# Altium schematic sheet Amp_op2.SchDoc(Amp_op2)
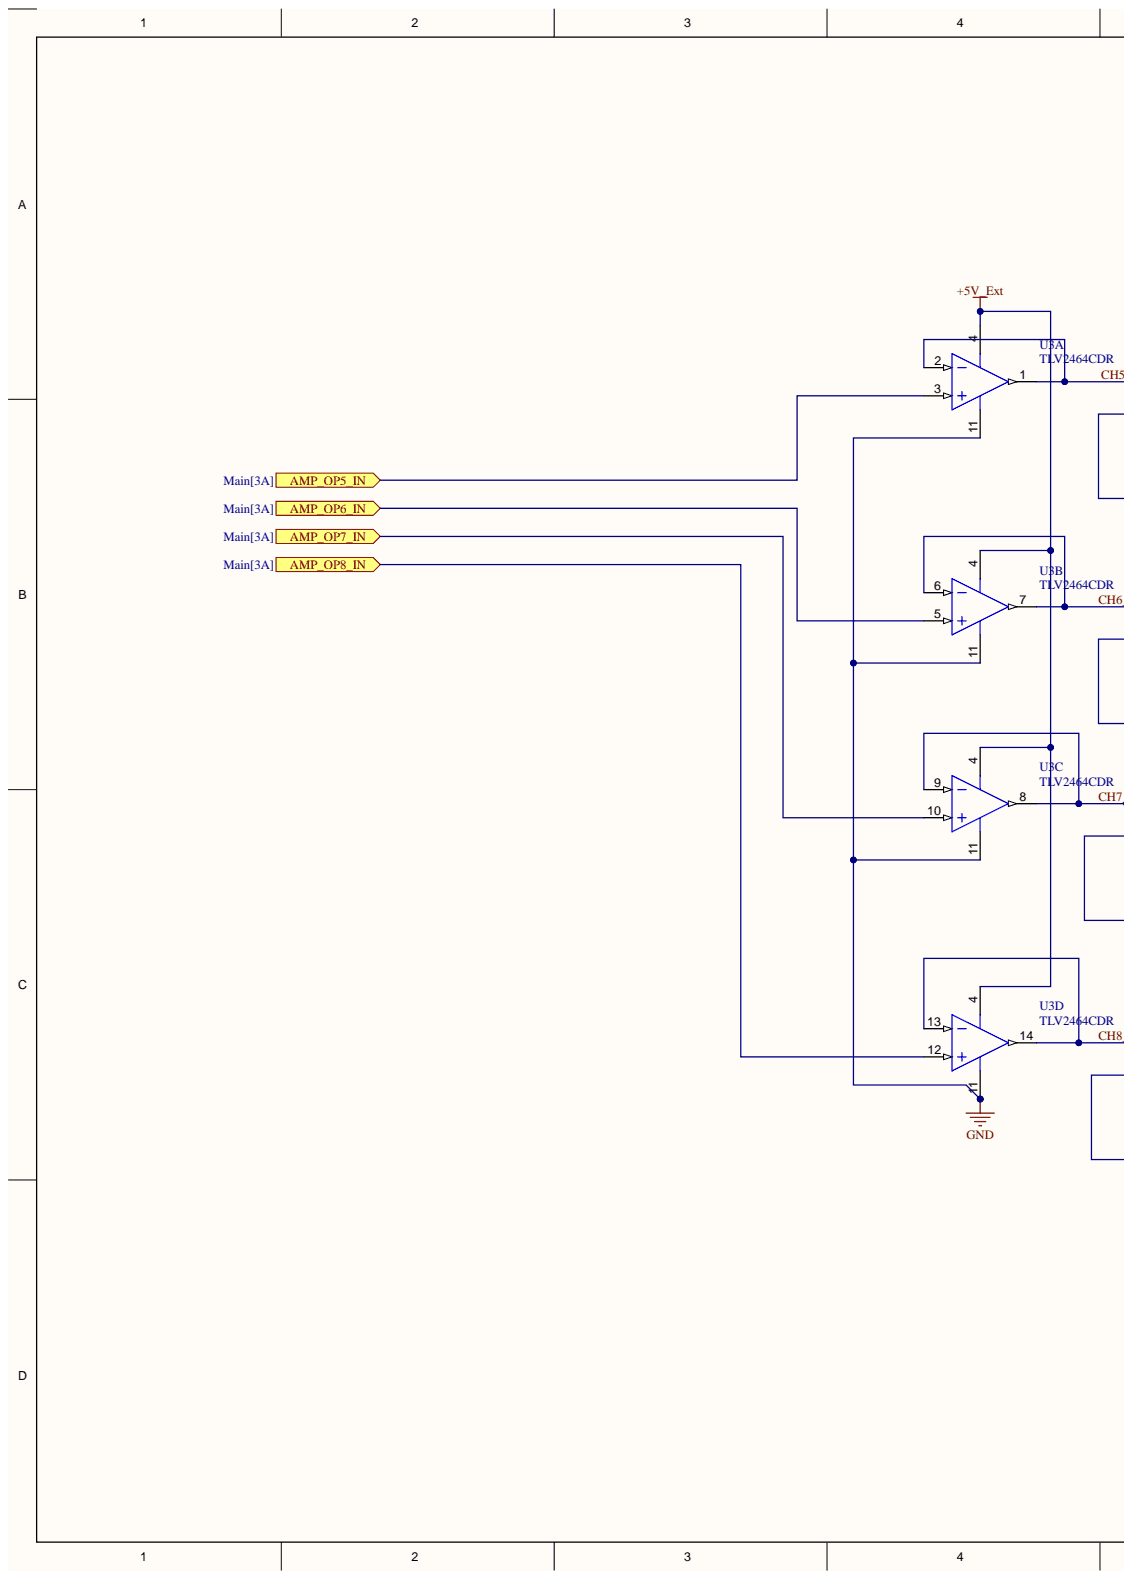
[diagram p0: Amp_op2.SchDoc, left]
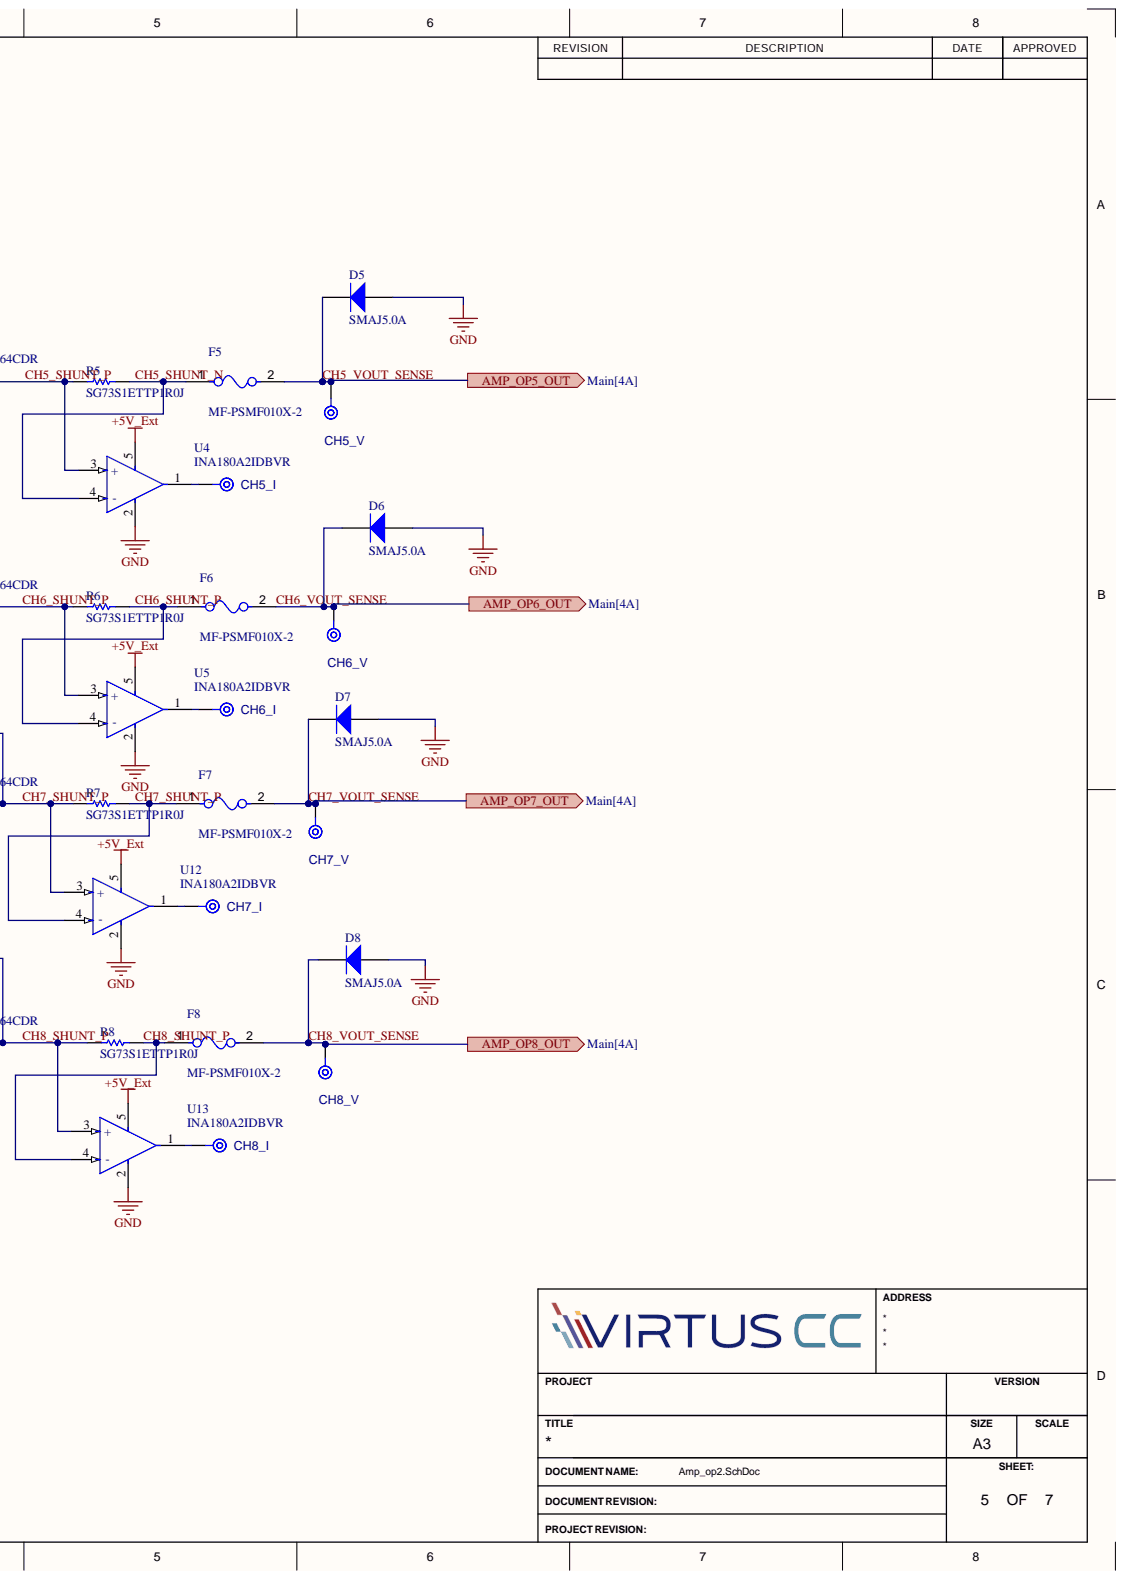
[diagram p1: Amp_op2.SchDoc, right]

## Parts
CH5_I  TPAD6R  [TP06R]  (value not drawn)  (no BOM)
CH5_V  TPAD6R  [TP06R]  (value not drawn)  (no BOM)
CH6_I  TPAD6R  [TP06R]  (value not drawn)  (no BOM)
CH6_V  TPAD6R  [TP06R]  (value not drawn)  (no BOM)
CH7_I  TPAD6R  [TP06R]  (value not drawn)  (no BOM)
CH7_V  TPAD6R  [TP06R]  (value not drawn)  (no BOM)
CH8_I  TPAD6R  [TP06R]  (value not drawn)  (no BOM)
CH8_V  TPAD6R  [TP06R]  (value not drawn)  (no BOM)
D5  SMAJ5.0A  [BOUR-SMA-2_V]
D6  SMAJ5.0A  [BOUR-SMA-2_V]
D7  SMAJ5.0A  [BOUR-SMA-2_V]
D8  SMAJ5.0A  [BOUR-SMA-2_V]
F5  MF-PSMF010X-2  [FP-MF-PSMF-MFG]
F6  MF-PSMF010X-2  [FP-MF-PSMF-MFG]
F7  MF-PSMF010X-2  [FP-MF-PSMF-MFG]
F8  MF-PSMF010X-2  [FP-MF-PSMF-MFG]
R5  SG73S1ETTP1R0J  [RESC1005X40X25LL10T10]
R6  SG73S1ETTP1R0J  [RESC1005X40X25LL10T10]
R7  SG73S1ETTP1R0J  [RESC1005X40X25LL10T10]
R8  SG73S1ETTP1R0J  [RESC1005X40X25LL10T10]
U3  TLV2464CDR  [D0014A_N]  (parts A,B,C,D)
U4  INA180A2IDBVR  [FP-DBV0005A-MFG]
U5  INA180A2IDBVR  [FP-DBV0005A-MFG]
U12  INA180A2IDBVR  [FP-DBV0005A-MFG]
U13  INA180A2IDBVR  [FP-DBV0005A-MFG]

## Ports
AMP_OP5_IN, AMP_OP5_OUT, AMP_OP6_IN, AMP_OP6_OUT, AMP_OP7_IN, AMP_OP7_OUT, AMP_OP8_IN, AMP_OP8_OUT

## Nets
+5V_Ext: U3.4 U4.5 U5.5 U12.5 U13.5
GND: D5.1 D6.1 D7.1 D8.1 U3.11 U4.2 U5.2 U12.2 U13.2
AMP_OP5_IN: U3.3 ; port AMP_OP5_IN
AMP_OP6_IN: U3.5 ; port AMP_OP6_IN
AMP_OP7_IN: U3.10 ; port AMP_OP7_IN
AMP_OP8_IN: U3.12 ; port AMP_OP8_IN
CH5_SHUNT_N: F5.1 R5.2 U4.4(-)
CH5_SHUNT_P: R5.1 U3.1 U3.2 U4.3(+)
CH5_VOUT_SENSE: CH5_V.1 D5.2 F5.2 ; port AMP_OP5_OUT
CH6_SHUNT_P: F6.1 R6.1 R6.2 U3.6 U3.7 U5.3(+) U5.4(-)
CH6_VOUT_SENSE: CH6_V.1 D6.2 F6.2 ; port AMP_OP6_OUT
CH7_SHUNT_P: F7.1 R7.1 R7.2 U3.8 U3.9 U12.3(+) U12.4(-)
CH7_VOUT_SENSE: CH7_V.1 D7.2 F7.2 ; port AMP_OP7_OUT
CH8_SHUNT_P: F8.1 R8.1 R8.2 U3.13 U3.14 U13.3(+) U13.4(-)
CH8_VOUT_SENSE: CH8_V.1 D8.2 F8.2 ; port AMP_OP8_OUT
NetCH5_I_1: CH5_I.1 U4.1
NetCH6_I_1: CH6_I.1 U5.1
NetCH7_I_1: CH7_I.1 U12.1
NetCH8_I_1: CH8_I.1 U13.1

## Library data of the parts
CH5_I CH5_V CH6_I CH6_V CH7_I CH7_V CH8_I CH8_V = CMP-00028-00002-2: 0.6mm round; Pins: 1; Library Name: UFCG - Universidade Federal de Campina Grande
D5-D8 = CMP-2000-07090-1: SMAJ Transient Voltage Suppressor Diode Series, 3.5 V, -55 to 150 degC, 2-Pin SMA, RoHS, Tape and Reel; Manufacturer: Bourns; Catalog Drawings: SMAJSeriesFootprintSMAJ,SMBJSeries; Current - Peak Pulse (10/1000µs): 43.5A; EDA / CAD Models: DownloadfromAcceleratedDesigns; Featured Product: SMAJ/SMBJ/SMCJ/SMLJDiscreteTVSDiodes; Online Catalog: SMAJSeries; Other Names: SMAJ5.0ABDKR; Power - Peak Pulse: 400W; Power Line Protection: No; Type: Zener; Unidirectional Channels: 1; Voltage - Breakdown (Min): 6.4V; Voltage - Clamping (Max) @ Ipp: 9.2V; Voltage - Reverse Standoff (Typ): 5V; Applications: GeneralPurpose; Bidirectional Channels: -; Capacitance @ Frequency: -; Category: CircuitProtection; Component Kind: Standard; Datasheet URL: https://datasheet.ciiva.com/25624/0900766b8112213b-25624680.pdf; Datasheet Version: 08/2012; Family: TVS-Diodes; Library Name: Altium Content Vault; Manufacturer URL: http://www.bourns.com/; Mounting Technology: SurfaceMount; Mounting Type: SurfaceMount; Operating Temperature: -55°C~150°C(TJ); Package / Case: DO-214AC,SMA; Package Description: 2-Pin Surface Mount Device, Body 4.315 x 2.605 mm; Package Reference: SMA-2; Packaging: Digi-Reel®; RoHS Information: SMAJSeriesMaterialDeclaration; Series: SMAJ; Standard Package: 1; Supplier Device Package: SMA(DO-214AC)
F5-F8 = CMP-07400-000017-1: Polymeric PTC Resettable Fuse 15V 100mA Ih Surface Mount 0805 (2012 Metric), Concave; Manufacturer: Bourns Inc.; Current Rating: 40A; Hold Current: 0.1A; Resistance: 1-7.5Ω; Trip Current: 0.3A; Trip Time: 1.5s; Value: 1-7.5Ω; Ambient Temperature Range High: +85°C; Ambient Temperature Range Low: -40°C; Automotive: No; Category: Fuse; Component Kind: Standard; ComponentLink2Description: Datasheet; ComponentLink2URL: https://www.bourns.com/docs/Product-Datasheets/mfpsmf.pdf; Device Class L1: Circuit Protection; Device Class L2: PTC Resettable Fuses; Device Class L3: unset; Digikey Description: PTC RESET FUSE 15V 100MA 0805; Height: 0.85mm; Ipc Land Pattern Name: CAPC215135X67; Lead Free: Yes; Library Name: Altium Content Vault; Manufacturer Part Number: MF-PSMF010X-2; Mouser Description: Resettable Fuses - PPTC 0.10A 15V 1ohm; Octopart: https://octopart.com/mf-psmf010x-2-bourns-7483441; Package: MF-PSMF; RoHS: TRUE; Temperature: -40°C to +85°C; Temperature Range High: +85°C; Temperature Range Low: -40°C; Voltage Rating: 15V
R5-R8 = CMP-2002-04124-1: Manufacturer: KOA Speer; Component Kind: Standard; Library Name: Altium Content Vault; Part Number: SG73S1ETTP1R0J
U3 = CMP-0271-00006-3: Quad Low Power, Rail-to-Rail Input / Output Operational Amplifier, 2.7 to 6 V, 0 to 70 degC, 14-pin SOIC (D14), Green (RoHS & no Sb/Br); Manufacturer: Texas Instruments; CMRR(Min)(dB): 66; IIB(Max)(pA): 14000; Iq per channel(Max)(mA): 0.575; Number of Channels: 4; Offset Drift(Typ)(uV/C): 2; Slew Rate(Typ)(V/us): 1.6; Sub Family: High Output Current Amplifier (>=50mA), Low Supply Voltage Amplifier (<=2.7V), Precision Amplifier; Total Supply Voltage(Max)(+5V is 5, +/-5V is 10): 6; Total Supply Voltage(Min)(+5V is 5, +/-5V is 10): 2.7; Vn at 1kHz(Typ)(nV/rtHz): 11; Code_JEDEC: MS-012; Component Kind: Standard; ComponentLink1Description: Manufacturer URL; ComponentLink1URL: http://www.ti.com/; ComponentLink2Description: Datasheet; ComponentLink2URL: http://www.ti.com/general/docs/lit/getliterature.tsp?genericPartNumber=TLV2464&fileType=pdf; ComponentLink3Description: Package Specification; ComponentLink3URL: http://www.ti.com/lit/ml/mpds177g/mpds177g.pdf; DatasheetVersion: SLOS220J; Eco-Plan: Green (RoHS & no Sb/Br); Library Name: Altium Content Vault; Mounting Technology: Surface Mount; PackageDescription: 14-Pin Plastic Small Outline, Body 8.65 x 3.9 mm, Pitch 1.27 mm; PackageReference: D0014A; PackageVersion: 4040047-5/M, 06/2011; PartNumber: TLV2464CDR; Vio (25C)(Max)(mV): 2
U4 U5 U12 U13 = CMP-04895-000287-1: IC CURR SENSE 1 CIRCUIT SOT23-5; -3db Bandwidth: 350kHz; Input Bias Current: 100nA; Input Offset Voltage (Vos): 100uV; Number of Circuits: 1; Operating Supply Current: 260uA; Slew Rate: 2V/µs; Case/Package: SOT-23-5; Component Kind: Standard; Library Name: Altium Content Vault; Max Operating Temperature: 125°C; Min Operating Temperature: -40°C; Mount: Surface Mount; Packaging: Tape and Reel; RoHS Compliant: Yes
